# Altium schematic sheet RPi Stepper Hat V1.0.SchDoc
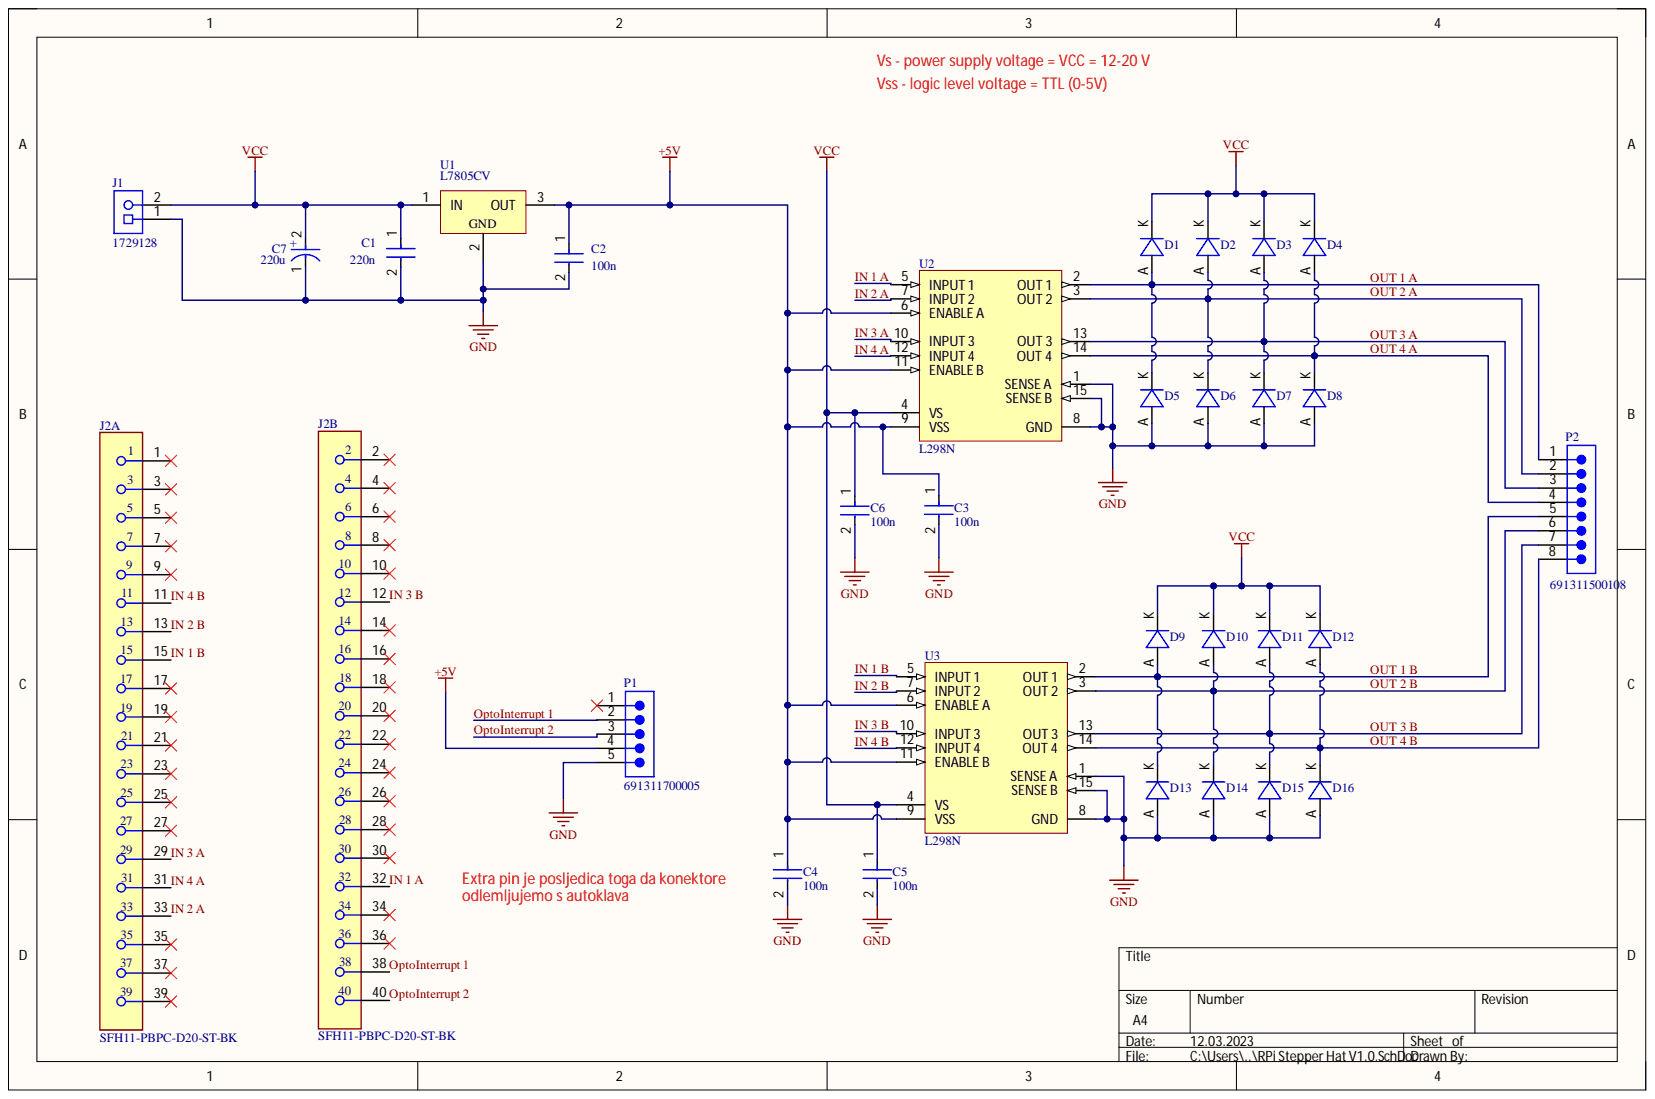
[diagram p0: RPi Stepper Hat V1.0.SchDoc]

## Parts
C1  220n  [FP-RCE1K1-2_5-MFG]
C2  100n  [FP-RDE0P1-MFG]
C3  100n  [FP-RDE0P1-MFG]
C4  100n  [FP-RDE0P1-MFG]
C5  100n  [FP-RDE0P1-MFG]
C6  100n  [FP-RDE0P1-MFG]
C7  220u  [FP-RAD-TH-D_10_0_5-L_25_2-MFG]
D1  RFN2VWM2STR  [DIOM4326X24N]  (value not drawn)
D2  RFN2VWM2STR  [DIOM4326X24N]  (value not drawn)
D3  RFN2VWM2STR  [DIOM4326X24N]  (value not drawn)
D4  RFN2VWM2STR  [DIOM4326X24N]  (value not drawn)
D5  RFN2VWM2STR  [DIOM4326X24N]  (value not drawn)
D6  RFN2VWM2STR  [DIOM4326X24N]  (value not drawn)
D7  RFN2VWM2STR  [DIOM4326X24N]  (value not drawn)
D8  RFN2VWM2STR  [DIOM4326X24N]  (value not drawn)
D9  RFN2VWM2STR  [DIOM4326X24N]  (value not drawn)
D10  RFN2VWM2STR  [DIOM4326X24N]  (value not drawn)
D11  RFN2VWM2STR  [DIOM4326X24N]  (value not drawn)
D12  RFN2VWM2STR  [DIOM4326X24N]  (value not drawn)
D13  RFN2VWM2STR  [DIOM4326X24N]  (value not drawn)
D14  RFN2VWM2STR  [DIOM4326X24N]  (value not drawn)
D15  RFN2VWM2STR  [DIOM4326X24N]  (value not drawn)
D16  RFN2VWM2STR  [DIOM4326X24N]  (value not drawn)
J1  1729128  [PHOE-1729128-2_V]
J2  SFH11-PBPC-D20-ST-BK  [FP-SFH11-PBPC-D20-ST-BK-MFG]  (parts A,B)
P1  691311700005  [691311700005]
P2  691311500108  [691311500108]
U1  L7805CV  [TO220]
U2  L298N  [STM-FM-15]
U3  L298N  [STM-FM-15]

## Nets
+5V: C2.1 C3.1 C4.1 P1.4 U1.3 U2.6(ENABLE_A) U2.9(VSS) U2.11(ENABLE_B) U3.6(ENABLE_A) U3.9(VSS) U3.11(ENABLE_B)
GND: C1.2 C2.2 C3.2 C4.2 C5.2 C6.2 C7.1 D5.A D6.A D7.A D8.A D13.A D14.A D15.A D16.A J1.1 P1.5 U1.2 U2.1(SENSE_A) U2.8(GND) U2.15(SENSE_B) U3.1(SENSE_A) U3.8(GND) U3.15(SENSE_B)
VCC: C1.1 C5.1 C6.1 C7.2 D1.K D2.K D3.K D4.K D9.K D10.K D11.K D12.K J1.2 U1.1 U2.4(VS) U3.4(VS)
IN 1 A: J2.32 U2.5(INPUT_1)
IN 1 B: J2.15 U3.5(INPUT_1)
IN 2 A: J2.33 U2.7(INPUT_2)
IN 2 B: J2.13 U3.7(INPUT_2)
IN 3 A: J2.29 U2.10(INPUT_3)
IN 3 B: J2.12 U3.10(INPUT_3)
IN 4 A: J2.31 U2.12(INPUT_4)
IN 4 B: J2.11 U3.12(INPUT_4)
OptoInterrupt 1: J2.38 P1.2
OptoInterrupt 2: J2.40 P1.3
OUT 1 A: D1.A D5.K P2.1 U2.2(OUT_1)
OUT 1 B: D9.A D13.K P2.5 U3.2(OUT_1)
OUT 2 A: D2.A D6.K P2.2 U2.3(OUT_2)
OUT 2 B: D10.A D14.K P2.6 U3.3(OUT_2)
OUT 3 A: D3.A D7.K P2.3 U2.13(OUT_3)
OUT 3 B: D11.A D15.K P2.7 U3.13(OUT_3)
OUT 4 A: D4.A D8.K P2.4 U2.14(OUT_4)
OUT 4 B: D12.A D16.K P2.8 U3.14(OUT_4)

Not connected: J2 1 2 3 4 5 6 7 8 9 10 14 16 17 18 19 20 21 22 23 24 25 26 27 28 30 34 35 36 37 39; P1 1

## Library data of the parts
C1 = CMP-06035-036150-1: Cap Ceramic Monolithic 220nF 50V 10% Thru-Hole Bulk RCER71H224K1M1H03A; Dielectric: X7R; Lead Diameter: 0.5mm; Lead/Base Style: Formed Leads; Capacitance: 220nF; Case/Package: Radial; Component Kind: Standard; Height: 3.5mm; Height - Seated (Max): 0.138inch; Lead Pitch: 0.197inch; Length: 0.157inch; Library Name: Altium Content Vault; Max Operating Temperature: 125°C; Min Operating Temperature: -55°C; Mount: Through Hole; Package Quantity: 1; Packaging: Tape and Reel; Ratings: AEC-Q200; Resistor Type: Ceramic; Termination: Radial; Tolerance: 10%; Voltage Rating: 50V; Voltage Rating (DC): 50V; Width: 0.098inch
C2-C6 = CMP-06035-046728-1: Ceramic Capacitor 100nF ±10% 25V X7R Radial RDER71E104K0S1H03A; Lead Diameter: 0.5mm; Capacitance: 100nF; Case/Package: Radial; Component Kind: Standard; Dielectric: X7R; Height: 3.5mm; Height - Seated (Max): 0.236inch; Lead Free: Lead Free; Lead Pitch: 0.098inch; Length: 0.197inch; Library Name: Altium Content Vault; Max Operating Temperature: 125°C; Min Operating Temperature: -55°C; Mount: Through Hole; Package Quantity: 2000; Packaging: Tape and Reel; Resistor Type: Ceramic; Termination: Radial; Tolerance: 10%; Voltage Rating: 25V; Voltage Rating (DC): 25V; Width: 3.5mm
C7 = CMP-05427-000604-1: Aluminum Electrolytic Capacitors (Radial Lead Type) 220uF ±20% 63V EEUFR1J221LB; ESR (Equivalent Series Resistance): 33mR; Lead Diameter: 0.6mm; Life (Hours): 10000Hour; Polarity: Polar; Ripple Current: 1.5A; Capacitance: 220uF; Case/Package: Radial; Component Kind: Standard; Construction: Cylindrical; Diameter: 10mm; Height: 25mm; Height - Seated (Max): 1.063inch; Impedance: 33mR; Lead Free: Lead Free; Lead Pitch: 5mm; Length: 25mm; Library Name: Altium Content Vault; Max Operating Temperature: 105°C; Min Operating Temperature: -40°C; Mount: Through Hole; Package Quantity: 500; Packaging: Tape and Reel; Radiation Hardening: No; REACH SVHC: Yes; RoHS Compliant: Yes; Series: FR; Termination: Radial; Tolerance: 20%; Voltage Rating: 63V; Voltage Rating (DC): 63V
J1 = CMP-2000-05815-1: PCB Terminal Block, 13.5 A, 400 V, 2-Pin THD, RoHS, Bulk; Manufacturer: Phoenix Contact; Current Rating: 13500mA; No. of Positions: 2; No. of Rows: 1; Package Version: 03/2015; Pitch: 5.08mm; Voltage Rating: 400V; Component Kind: Standard; Datasheet URL: https://datasheet.ciiva.com/16046/1729128-16046181.pdf; Datasheet Version: 12/2013; Library Name: Altium Content Vault; Manufacturer URL: http://www.phoenixcontact.com/; Mounting Technology: ThroughHole; Orientation: Horizontal; Package Description: 2-Pin Through Hole Device, Body 8.1 x 10.16 mm, Pitch 5.08 mm; Package Reference: 1729128-2; Package URL: https://www.phoenixcontact.com/online/portal/pi/pxc/product_detail_page/!ut/p/b1/3VdJjqNKFDxLH8AFycySyWY2ozFsEPOMMQaDOX1XedGL_1Vdm5YXJleknhSKeBkvI6EA8ncAo3AYoDhBQGco6KN7VURTdemj9us_IEKEZo_YHiPAEWEFmDGOHMORkqKYCORBZxgL7ZoaZYcbFFUZ3fqxSY6GOuxydWUuHqz1ynmMFygu583Bob0Ewr6Sd_l-5m-kAueHiB0jpRwZwe58wwtkpxtMYd_LmGcDRU02dRNEyWt6Y2ti5pSyJ_reIkzIulrqApdnbT11V5jnCKbYNCvhEM1MCpPByat_X379-iThf08CI5GfOPqfBeS3BRoBOdD55AKVv0iLwCMq-2BrzXWSGRH7pUGD9uwXQjiph3xL0DKQwdGa0JImiIOmBNOestqgwTHSSs2oOep-78apyXQBvNi8ZLaFjvjWvcaUEBu0MC6Wbe-d6mB0FAebo2lUWinqYaZs5DAYzV0RP9yitUjnGJ0iI9xhZkT42OCQNUJJhkgWINNQLP2JFP6OpMg3JCVg70jqHT0lvKOnhHf0lPuOnnLf0VPuWx6_dxwUIvwk5WMkT0kLL1mh61l2yZVSzG3pYV_bqo-mj6uMKwKwdnnW8Jh5RTOJzMOA5c-eJDaZQw4mTMPH02PvS_ZAqNVjVTo2WyYLkwH_KU1mmmG5kjRhDqPXLwwVHvVOjCM60-fpXvTDfMGW1PVFVS1r4e4yVDk4ZdNbkUHvqPqQSeian4UD39fNKmachqdJ1NJbyk8rXT9mXTvPk3oE5meulKGgiruPJek-4I9hTT6G8ZLOyRSm2RRVbThERQad5OS28hdt4YW4vG0stgYYzsWsK4_7y6DZMjJSCqri1kyiZR5vD7pwIrNR7QwPHTcLTI5qT7eRlpHrdGiupYlqQDJ7zy_CND8fWWBoLRXxk1RVcXiBQwbTD4Xi7KL-FuO94t9xaqweXzH; Packing: Bulk; PartNumber: 1729128; RoHS: TRUE
J2 = CMP-25680-000025-1: CONN HDR 40POS 0.1 GOLD PCB; Connector Type: Header; Number of Positions: 40; Pitch: 0.1inch; Color: Black; Component Kind: Standard; Contact Plating: Gold; Lead Free: Lead Free; Library Name: Altium Content Vault; Mount: Through Hole; Number of Rows: 2; Package Quantity: 1; Packaging: Tray; Row Spacing: 0.1inch; Termination: Solder
P1 = CMP-1502-02029-2: NexarPartId: 18818307; Connector Type: PCB, Terminal Block; Current: 20A; Gender: Male; Number of Contacts: 5; Number of Levels: 1; Pitch: 5.0038mm; Voltage: 300V; Color: Green; Component Kind: Standard; Contact Gender: Male; Contact Material: Brass; Height: 12mm; Lead Pitch: 5mm; Length: 8.5mm; Library Name: Altium Content Vault; Mount: Through Hole; Number of Pins: 5; Number of Positions Per Level: 5; Number of Rows: 1; Orientation: Vertical; Packaging: Box; REACH SVHC: No SVHC; RoHS: Compliant; Termination: Solder; Width: 25mm
P2 = CMP-1502-02152-2: NexarPartId: 8917752; Current: 20A; Gender: Male; Number of Contacts: 8; Number of Levels: 1; Pitch: 5.08mm; Voltage: 300V; Color: Green; Component Kind: Standard; Contact Gender: Male; Contact Material: Brass; Contact Plating: Tin; Current Rating: 20A; Height: 12mm; Lead Pitch: 5.08mm; Length: 8.5mm; Library Name: Altium Content Vault; Mount: Through Hole; Number of Pins: 8; Number of Positions Per Level: 8; Number of Rows: 1; Orientation: Vertical; Packaging: Box; REACH SVHC: No SVHC; RoHS: Compliant; Termination: Solder; Width: 42.64mm
U1 = CMP-0244-00483-1: Positive Voltage Regulator, 5V, 3-Pin TO-220; Component Kind: Standard; ComponentLink1Description: Manufacturer URL; ComponentLink1URL: http://www.st.com; ComponentLink2Description: Datasheet; ComponentLink2URL: http://www.st.com/internet/com/TECHNICAL_RESOURCES/TECHNICAL_LITERATURE/DATASHEET/CD00000444.pdf; ComponentLink3Description: Package Specification; ComponentLink3URL: http://www.st.com/internet/com/TECHNICAL_RESOURCES/TECHNICAL_LITERATURE/PACKAGE_INFORMATION/CD00004649.pdf; DatasheetVersion: 16/11/2011; Library Name: Altium Content Vault; PackageDescription: TO, Thru-Hole, Vertical, Heatsink Mounted; 3 In-Line Leads; Pitch 2.54 mm; PackageReference: TO220; PackageVersion: ver3.0
U2 U3 = CMP-2000-06526-1: Dual Full-Bridge Driver, 4.8 to 46 V, -40 to 150 degC, 15-Pin FM (MW 15L), RoHS, Tube; Manufacturer: STMicroelectronics; PartNumber: L298; Driver Case Style: Multiwatt; Motor Type: FullBridge; No. of Outputs: 4Outputs; No. of Pins: 15Pins; Output Current: 2A; Output Voltage: 46V; Supply Voltage Max: 7V; Supply Voltage Min: 4.5V; SVHC: NoSVHC(17-Dec-2015); Automotive Qualification Standard: -; Component Kind: Standard; Datasheet URL: https://datasheet.ciiva.com/15109/getdatasheetpartid-50682-15109332.pdf; Datasheet Version: 01/2000; Library Name: Altium Content Vault; Manufacturer URL: http://www.st.com/; Mounting Technology: ThroughHole; MSL: -; Operating Temperature Max: 130°C; Operating Temperature Min: -25°C; Package Description: 15-Pin Flange Mount, Body 5 x 19.6 mm, Pitch 1.27 mm; Package Reference: FM-15; Packaging: Each; Product Range: -
D1-D16 = RFN2VWM2STR: Component Kind: Standard; Library Name: RPi Stepper Hat V1.0 SchLib.SchLib
